# Altium schematic sheet MagTrix_16.SchDoc(MagTrix_16)
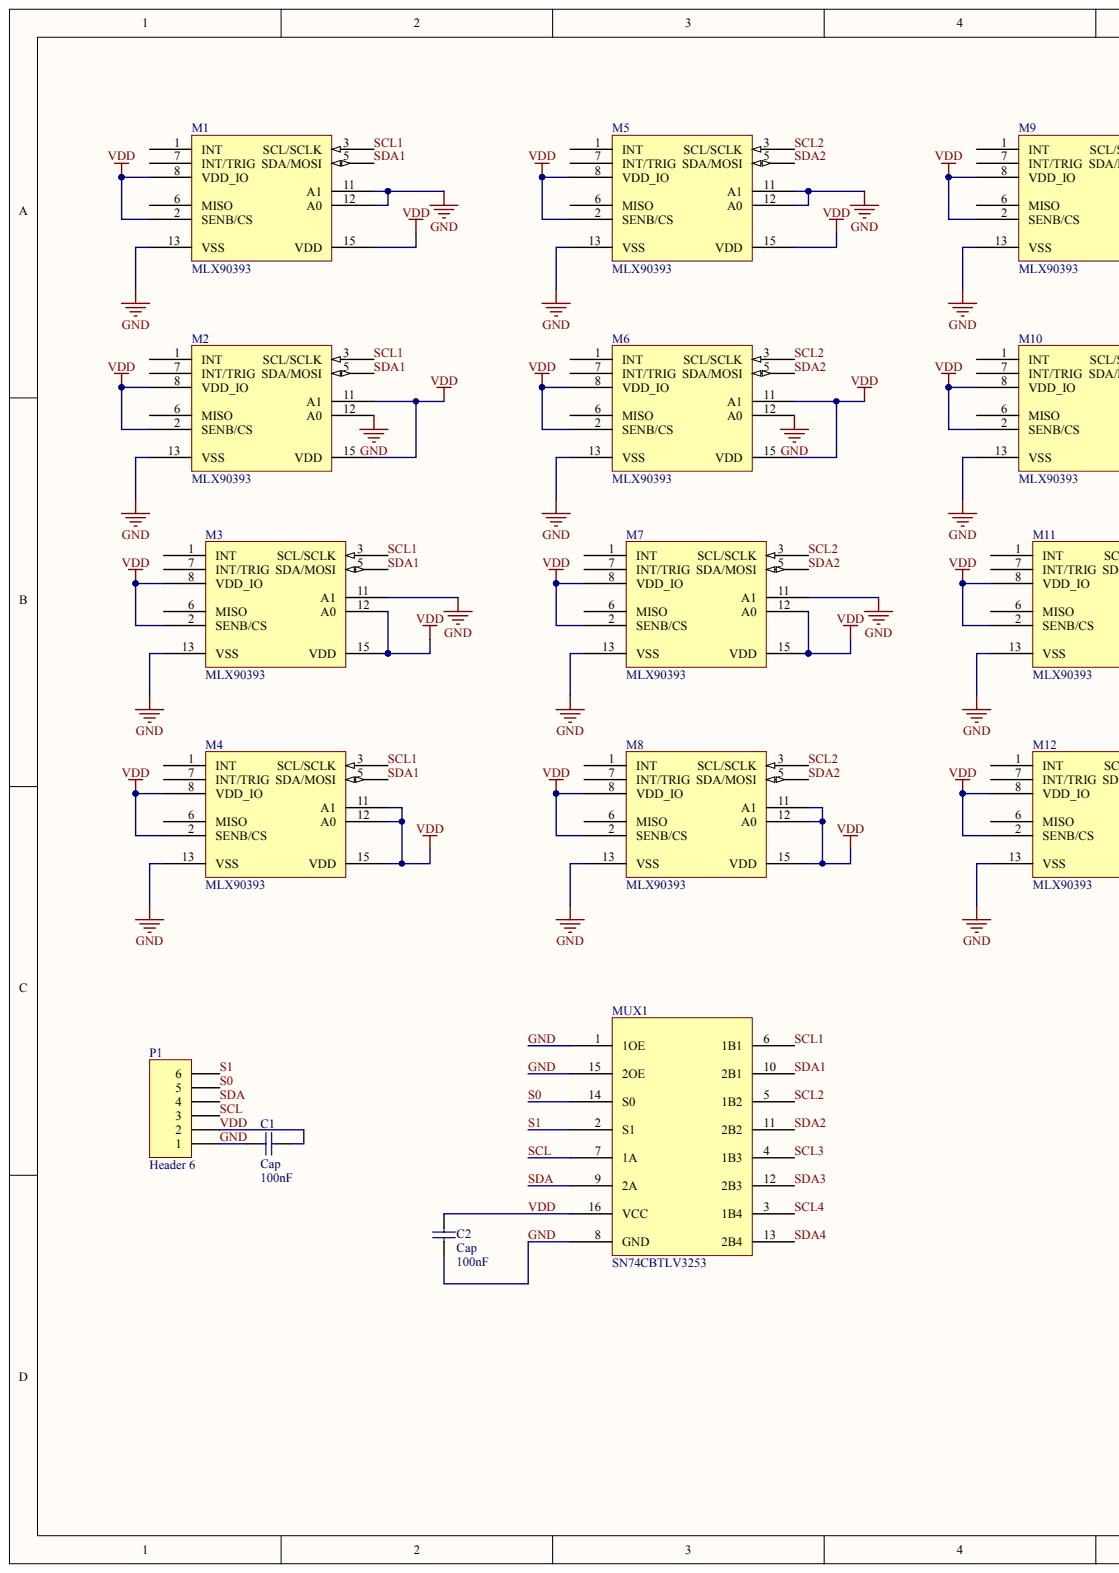
[diagram p0: MagTrix_16.SchDoc, left]
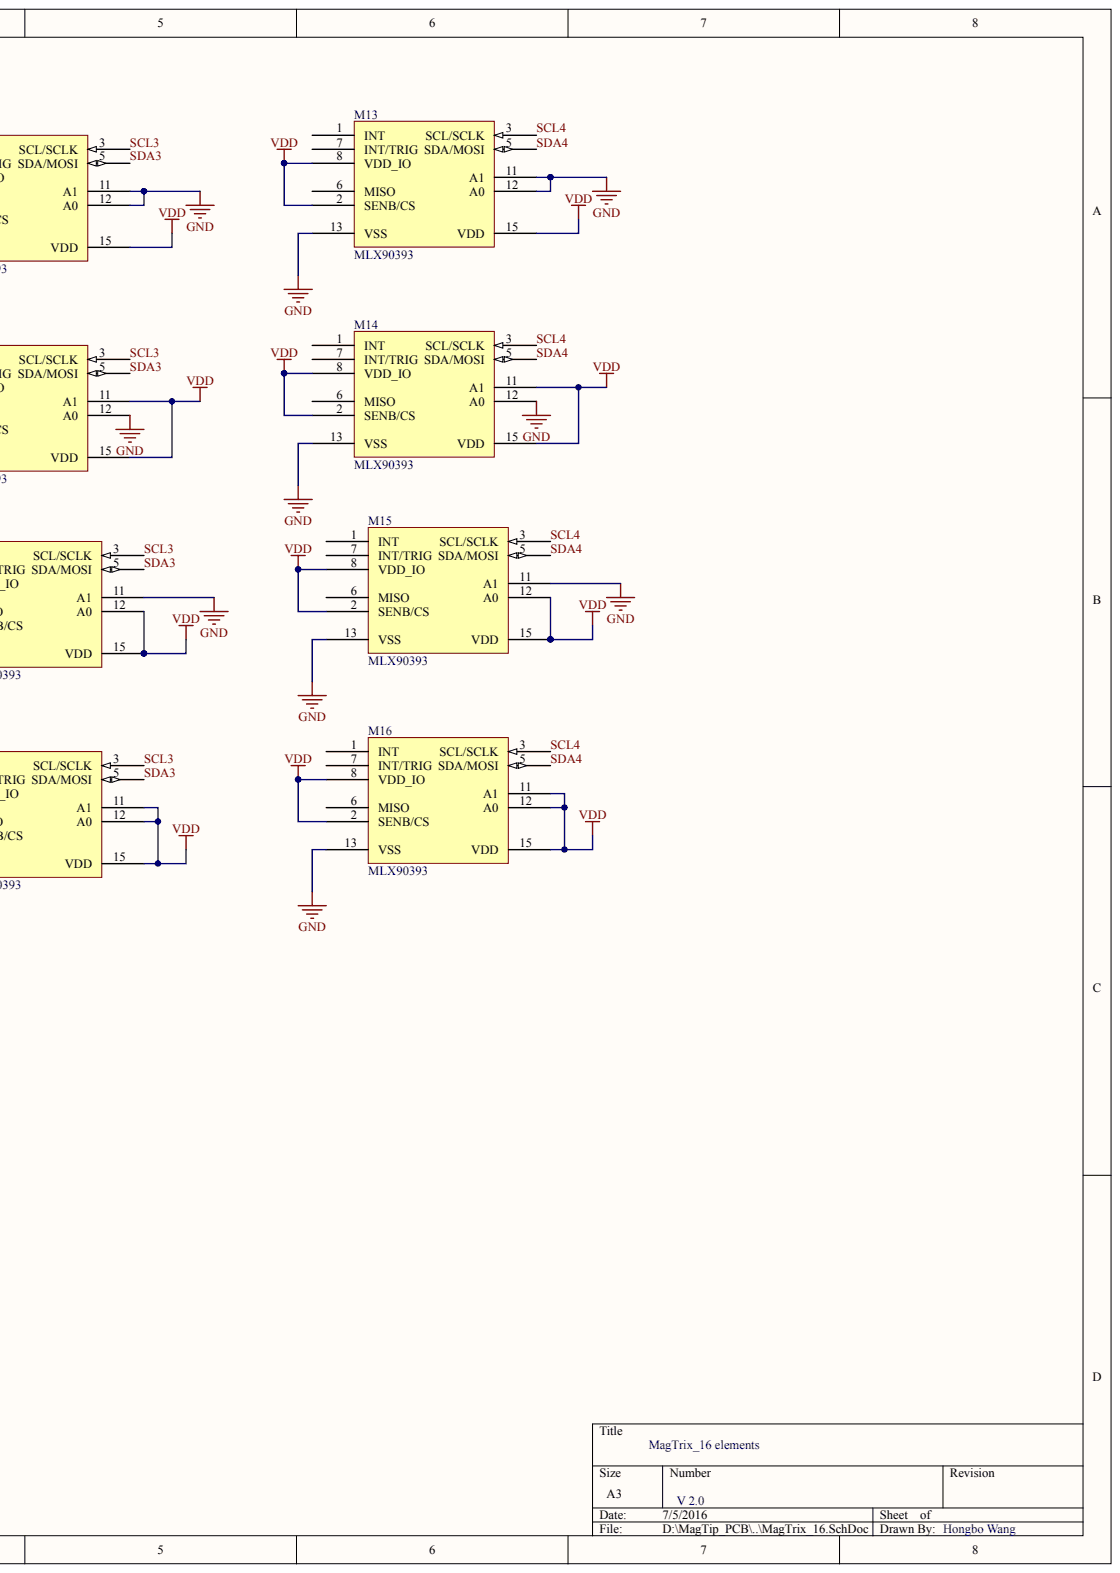
[diagram p1: MagTrix_16.SchDoc, right]

## Parts
C1  Cap  value 100nF
C2  Cap  value 100nF
M1  MLX90393
M2  MLX90393
M3  MLX90393
M4  MLX90393
M5  MLX90393
M6  MLX90393
M7  MLX90393
M8  MLX90393
M9  MLX90393
M10  MLX90393
M11  MLX90393
M12  MLX90393
M13  MLX90393
M14  MLX90393
M15  MLX90393
M16  MLX90393
MUX1  SN74CBTLV3253
P1  Header 6

## Nets
GND: C1.2 C2.2 M1.11(A1) M1.12(A0) M1.13(VSS) M2.12(A0) M2.13(VSS) M3.11(A1) M3.13(VSS) M4.13(VSS) M5.11(A1) M5.12(A0) M5.13(VSS) M6.12(A0) M6.13(VSS) M7.11(A1) M7.13(VSS) M8.13(VSS) M9.11(A1) M9.12(A0) M9.13(VSS) M10.12(A0) M10.13(VSS) M11.11(A1) M11.13(VSS) M12.13(VSS) M13.11(A1) M13.12(A0) M13.13(VSS) M14.12(A0) M14.13(VSS) M15.11(A1) M15.13(VSS) M16.13(VSS) MUX1.1(1OE) MUX1.8(GND) MUX1.15(2OE) P1.1
S0: MUX1.14(S0) P1.5
S1: MUX1.2(S1) P1.6
SCL: MUX1.7(1A) P1.3
SCL1: M1.3(SCL/SCLK) M2.3(SCL/SCLK) M3.3(SCL/SCLK) M4.3(SCL/SCLK) MUX1.6(1B1)
SCL2: M5.3(SCL/SCLK) M6.3(SCL/SCLK) M7.3(SCL/SCLK) M8.3(SCL/SCLK) MUX1.5(1B2)
SCL3: M9.3(SCL/SCLK) M10.3(SCL/SCLK) M11.3(SCL/SCLK) M12.3(SCL/SCLK) MUX1.4(1B3)
SCL4: M13.3(SCL/SCLK) M14.3(SCL/SCLK) M15.3(SCL/SCLK) M16.3(SCL/SCLK) MUX1.3(1B4)
SDA: MUX1.9(2A) P1.4
SDA1: M1.5(SDA/MOSI) M2.5(SDA/MOSI) M3.5(SDA/MOSI) M4.5(SDA/MOSI) MUX1.10(2B1)
SDA2: M5.5(SDA/MOSI) M6.5(SDA/MOSI) M7.5(SDA/MOSI) M8.5(SDA/MOSI) MUX1.11(2B2)
SDA3: M9.5(SDA/MOSI) M10.5(SDA/MOSI) M11.5(SDA/MOSI) M12.5(SDA/MOSI) MUX1.12(2B3)
SDA4: M13.5(SDA/MOSI) M14.5(SDA/MOSI) M15.5(SDA/MOSI) M16.5(SDA/MOSI) MUX1.13(2B4)
VDD: C1.1 C2.1 M1.2(SENB/CS) M1.8(VDD_IO) M1.15(VDD) M2.2(SENB/CS) M2.8(VDD_IO) M2.11(A1) M2.15(VDD) M3.2(SENB/CS) M3.8(VDD_IO) M3.12(A0) M3.15(VDD) M4.2(SENB/CS) M4.8(VDD_IO) M4.11(A1) M4.12(A0) M4.15(VDD) M5.2(SENB/CS) M5.8(VDD_IO) M5.15(VDD) M6.2(SENB/CS) M6.8(VDD_IO) M6.11(A1) M6.15(VDD) M7.2(SENB/CS) M7.8(VDD_IO) M7.12(A0) M7.15(VDD) M8.2(SENB/CS) M8.8(VDD_IO) M8.11(A1) M8.12(A0) M8.15(VDD) M9.2(SENB/CS) M9.8(VDD_IO) M9.15(VDD) M10.2(SENB/CS) M10.8(VDD_IO) M10.11(A1) M10.15(VDD) M11.2(SENB/CS) M11.8(VDD_IO) M11.12(A0) M11.15(VDD) M12.2(SENB/CS) M12.8(VDD_IO) M12.11(A1) M12.12(A0) M12.15(VDD) M13.2(SENB/CS) M13.8(VDD_IO) M13.15(VDD) M14.2(SENB/CS) M14.8(VDD_IO) M14.11(A1) M14.15(VDD) M15.2(SENB/CS) M15.8(VDD_IO) M15.12(A0) M15.15(VDD) M16.2(SENB/CS) M16.8(VDD_IO) M16.11(A1) M16.12(A0) M16.15(VDD) MUX1.16(VCC) P1.2

Not connected: M1 1(INT) 6(MISO) 7(INT/TRIG); M2 1(INT) 6(MISO) 7(INT/TRIG); M3 1(INT) 6(MISO) 7(INT/TRIG); M4 1(INT) 6(MISO) 7(INT/TRIG); M5 1(INT) 6(MISO) 7(INT/TRIG); M6 1(INT) 6(MISO) 7(INT/TRIG); M7 1(INT) 6(MISO) 7(INT/TRIG); M8 1(INT) 6(MISO) 7(INT/TRIG); M9 1(INT) 6(MISO) 7(INT/TRIG); M10 1(INT) 6(MISO) 7(INT/TRIG); M11 1(INT) 6(MISO) 7(INT/TRIG); M12 1(INT) 6(MISO) 7(INT/TRIG); M13 1(INT) 6(MISO) 7(INT/TRIG); M14 1(INT) 6(MISO) 7(INT/TRIG); M15 1(INT) 6(MISO) 7(INT/TRIG); M16 1(INT) 6(MISO) 7(INT/TRIG)

## Library data of the parts
C1 C2 M1-M16 MUX1 P1 = (no symbol name)
  C1 C2: Published: 8-Jun-2000; LatestRevisionDate: 29-May-2009; LatestRevisionNote: IPC-7351 Footprint Added.; PackageReference: C1206; PackageDescription: Chip Capacitor
  P1: LatestRevisionDate: 17-Jul-2002; LatestRevisionNote: Re-released for DXP Platform.
  C1 C2 P1: Publisher: Altium Limited
